# Altium schematic sheet ZYNQ_PMU.SchDoc(ZYNQ_PMU)
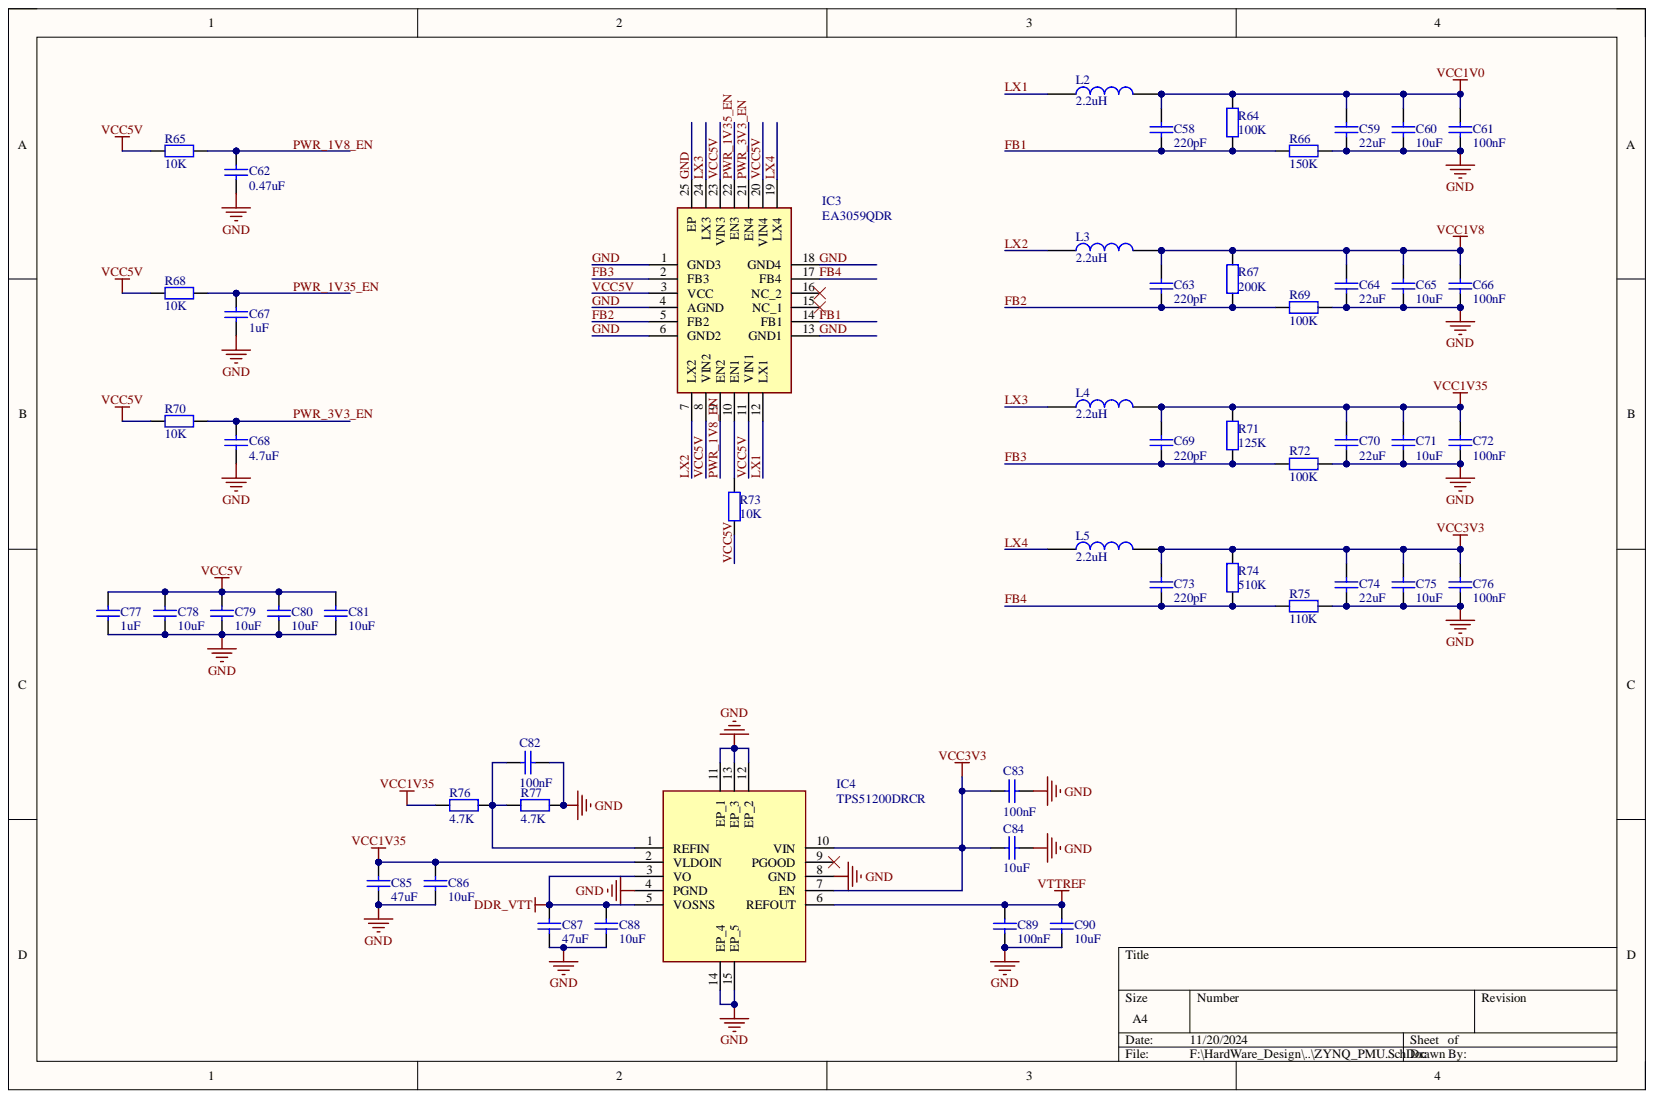
[diagram p0: ZYNQ_PMU.SchDoc]

## Parts
C58  220pF  [C 0402_L]
C59  22uF  [C 0603_L]
C60  10uF  [C 0603_L]
C61  100nF  [C 0402_L]
C62  0.47uF  [C 0402_L]
C63  220pF  [C 0402_L]
C64  22uF  [C 0603_L]
C65  10uF  [C 0603_L]
C66  100nF  [C 0402_L]
C67  1uF  [C 0402_L]
C68  4.7uF  [C 0402_L]
C69  220pF  [C 0402_L]
C70  22uF  [C 0603_L]
C71  10uF  [C 0603_L]
C72  100nF  [C 0402_L]
C73  220pF  [C 0402_L]
C74  22uF  [C 0603_L]
C75  10uF  [C 0603_L]
C76  100nF  [C 0402_L]
C77  1uF  [C 0402_L]
C78  10uF  [C 0603_L]
C79  10uF  [C 0603_L]
C80  10uF  [C 0603_L]
C81  10uF  [C 0603_L]
C82  100nF  [C 0402_L]
C83  100nF  [C 0402_L]
C84  10uF  [C 0603_L]
C85  47uF  [C 0805_L]
C86  10uF  [C 0603_L]
C87  47uF  [C 0805_L]
C88  10uF  [C 0603_L]
C89  100nF  [C 0402_L]
C90  10uF  [C 0603_L]
IC3  EA3059QDR  [QFN50P400X400X100-25N]
IC4  TPS51200DRCR  [TPS51200DRCR]
L2  2.2uH  [NR2520]
L3  2.2uH  [NR2520]
L4  2.2uH  [NR2520]
L5  2.2uH  [NR2520]
R64  100K  [R 0402_L]
R65  10K  [R 0402_L]
R66  150K  [R 0402_L]
R67  200K  [R 0402_L]
R68  10K  [R 0402_L]
R69  100K  [R 0402_L]
R70  10K  [R 0402_L]
R71  125K  [R 0402_L]
R72  100K  [R 0402_L]
R73  10K  [R 0402_L]
R74  510K  [R 0402_L]
R75  110K  [R 0402_L]
R76  4.7K  [R 0402_L]
R77  4.7K  [R 0402_L]

## Nets
DDR_VTT: C87.2 C88.1 IC4.3(VO) IC4.5(VOSNS)
VCC1V0: C58.2 C59.2 C60.2 C61.2 L2.2 R64.2
VCC1V8: C63.2 C64.2 C65.2 C66.2 L3.2 R67.2
VCC1V35: C69.2 C70.2 C71.2 C72.2 C85.2 C86.2 IC4.2(VLDOIN) L4.2 R71.2 R76.2
VCC3V3: C73.2 C74.2 C75.2 C76.2 C83.2 C84.2 IC4.7(EN) IC4.10(VIN) L5.2 R74.2
VTTREF: C89.1 C90.2 IC4.6(REFOUT)
FB1: C58.1 IC3.14(FB1) R64.1 R66.2
FB2: C63.1 IC3.5(FB2) R67.1 R69.2
FB3: C69.1 IC3.2(FB3) R71.1 R72.2
FB4: C73.1 IC3.17(FB4) R74.1 R75.2
GND: C59.1 C60.1 C61.1 C62.1 C64.1 C65.1 C66.1 C67.1 C68.1 C70.1 C71.1 C72.1 C74.1 C75.1 C76.1 C77.1 C78.1 C79.1 C80.1 C81.1 C82.1 C83.1 C84.1 C85.1 C86.1 C87.1 C88.2 C89.2 C90.1 IC3.1(GND3) IC3.4(AGND) IC3.6(GND2) IC3.13(GND1) IC3.18(GND4) IC3.25(EP) IC4.4(PGND) IC4.8(GND) IC4.11(EP_1) IC4.12(EP_2) IC4.13(EP_3) IC4.14(EP_4) IC4.15(EP_5) R66.1 R69.1 R72.1 R75.1 R77.1
LX1: IC3.12(LX1) L2.1
LX2: IC3.7(LX2) L3.1
LX3: IC3.24(LX3) L4.1
LX4: IC3.19(LX4) L5.1
PWR_1V8_EN: C62.2 IC3.9(EN2) R65.2
PWR_1V35_EN: C67.2 IC3.22(EN3) R68.2
PWR_3V3_EN: C68.2 IC3.21(EN4) R70.2
VCC5V: C77.2 C78.2 C79.2 C80.2 C81.2 IC3.3(VCC) IC3.8(VIN2) IC3.11(VIN1) IC3.20(VIN4) IC3.23(VIN3) R65.1 R68.1 R70.1 R73.1
NetC82_2: C82.2 IC4.1(REFIN) R76.1 R77.2
NetIC3_10: IC3.10(EN1) R73.2

Not connected: IC3 15(NC_1) 16(NC_2); IC4 9(PGOOD)

## Library data of the parts
C58-C81 = Cap: 贴片电容; Component Kind: Standard; Library Name: A_Schlib.SchLib
  C58 C63 C69 C73: value: 220pF
  C59 C64 C70 C74: value: 22uF
  C60 C65 C71 C75 C78-C81: value: 10uF
  C61 C66 C72 C76: value: 100nF
  C62: value: 0.47uF
  C67 C77: value: 1uF
  C68: value: 4.7uF
C82-C90 = Cap1: Component Kind: Standard; Library Name: 零散元件库.SCHLIB
  C82 C83 C89: value: 100nF
  C84 C86 C88 C90: value: 10uF
  C85 C87: value: 47uF
IC3 = EA3059QDR: Integrated Circuit; Manufacturer_Name: Ever Analog; Component Kind: Standard; Datasheet Link: https://datasheet.lcsc.com/lcsc/1811151429_Everanalog-IC-design-EA3059_C111586.pdf; Height: 1mm; Library Name: SamacSys.SchLib; Manufacturer_Part_Number: EA3059QDR
IC4 = TPS51200DRCR: Integrated Circuit; Manufacturer_Name: Texas Instruments; Arrow Part Number: TPS51200DRCR; Arrow Price/Stock: https://www.arrow.com/en/products/tps51200drcr/texas-instruments?region=nac; Component Kind: Standard; Datasheet Link: https://www.ti.com/lit/gpn/tps51200?HQS=ti-null-null-sf-df-pf-sep-wwe&DCM=yes; Height: 1mm; Library Name: SamacSys.SchLib; Manufacturer_Part_Number: TPS51200DRCR; Mouser Part Number: 595-TPS51200DRCR; Mouser Price/Stock: https://www.mouser.co.uk/ProductDetail/Texas-Instruments/TPS51200DRCR?qs=WxL8HmPi5r54D5pDlo3K5g%3D%3D
L2-L5 = 电感: 包含功率; Component Kind: Standard; Library Name: A_Schlib.SchLib
R64-R75 = Res: 通用; Component Kind: Standard; Library Name: A_Schlib.SchLib
  R64 R69 R72: value: 100K
  R65 R68 R70 R73: value: 10K
  R66: value: 150K
  R67: value: 200K
  R71: value: 125K
  R74: value: 510K
  R75: value: 110K
R76 R77 = Res: Component Kind: Standard; value: 4.7K; Library Name: 零散元件库.SCHLIB
